# Altium schematic sheet BMS_TopLevel.SchDoc
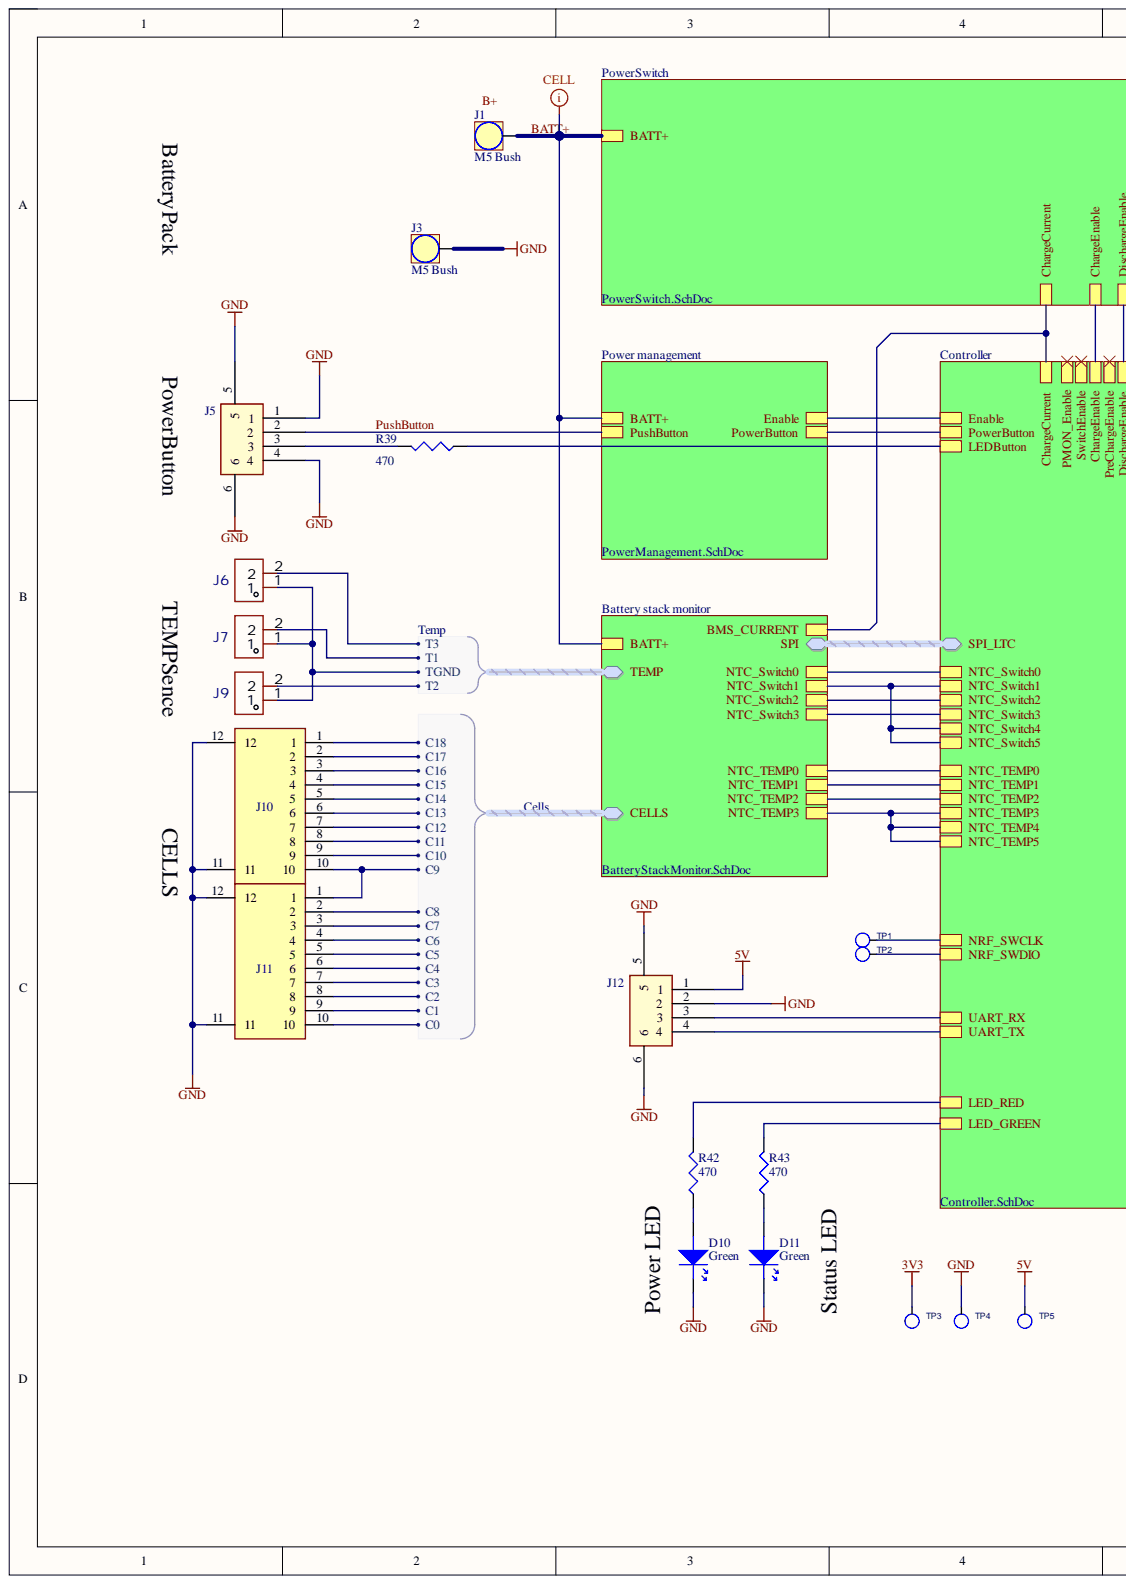
[diagram p0: BMS_TopLevel.SchDoc, left]
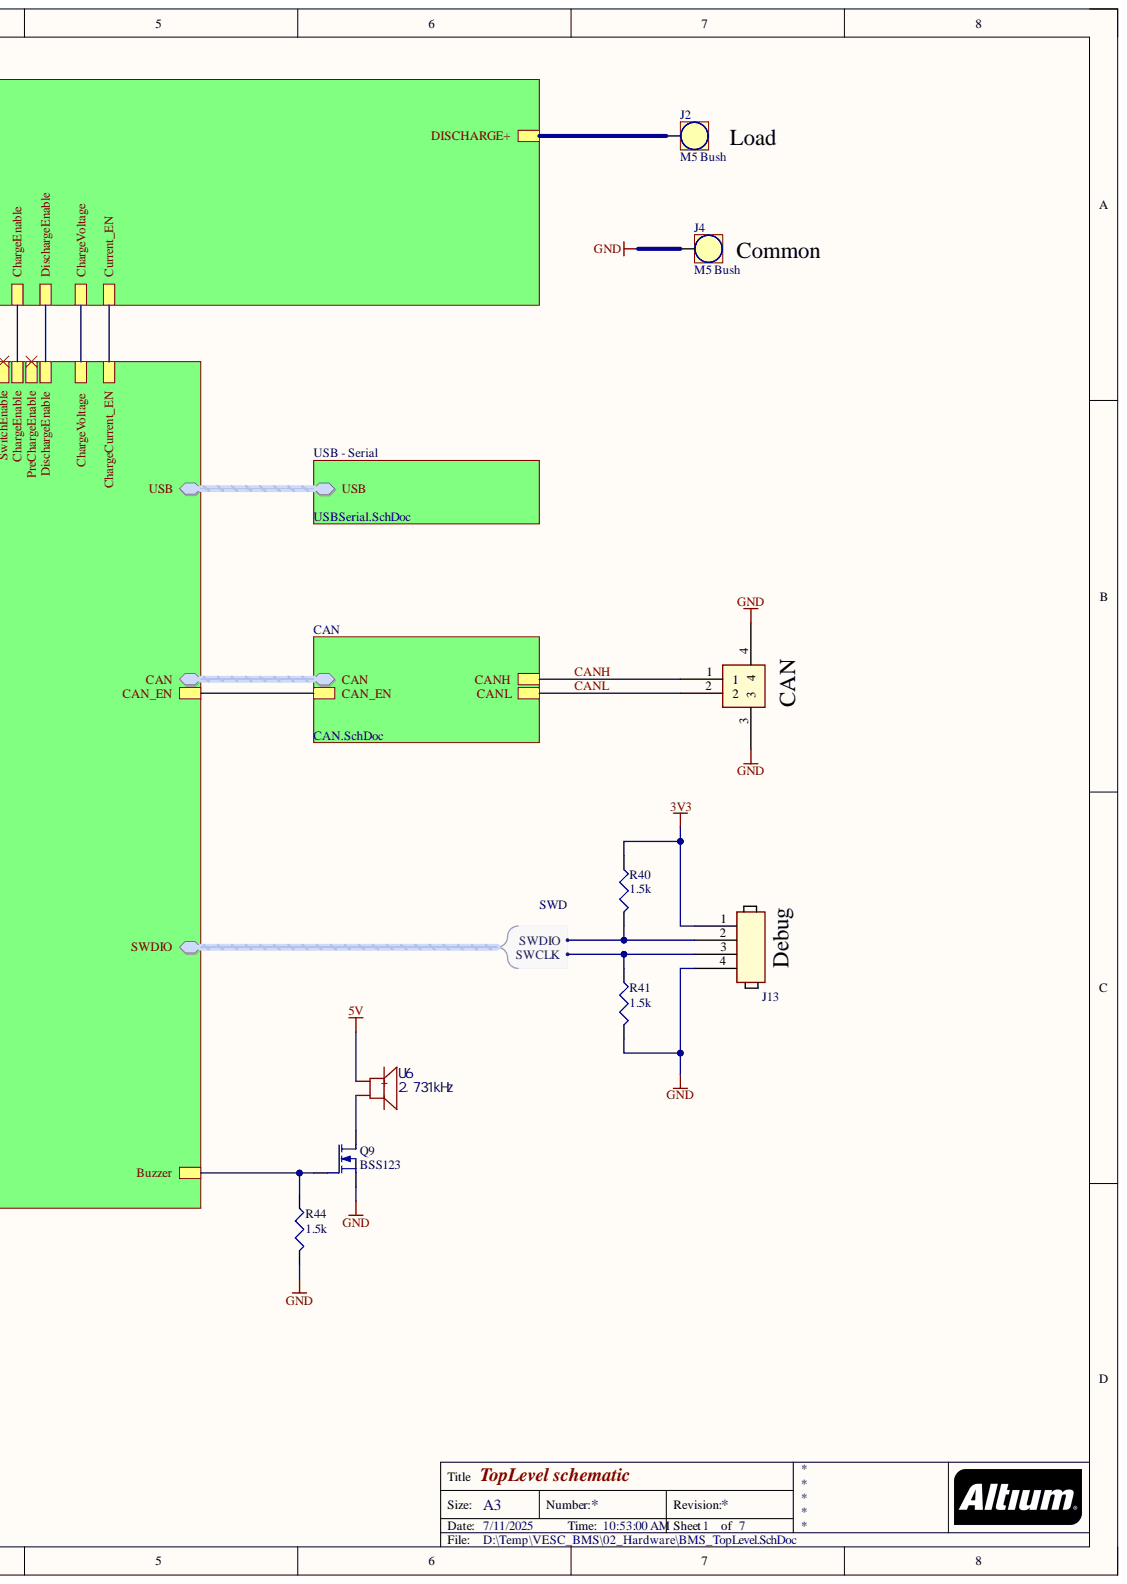
[diagram p1: BMS_TopLevel.SchDoc, right]

## Parts
D10  Green  [LED0603]
D11  Green  [LED0603]
J1  M5 Bush  [CONN-TH_T44001]
J2  M5 Bush  [CONN-TH_T44001]
J3  M5 Bush  [CONN-TH_T44001]
J4  M5 Bush  [CONN-TH_T44001]
J5  1.25-4PWTDK  [SM04B-GHS-TB]  (value not drawn)
J6  WAFER-XH254Z-2A  [CONN-TH_2P-P2.50_XH-2AW]  (value not drawn)
J7  WAFER-XH254Z-2A  [CONN-TH_2P-P2.50_XH-2AW]  (value not drawn)
J8  1.25-2PWTDK  [BM02B-GHS-TBT_1]  (value not drawn)
J9  WAFER-XH254Z-2A  [CONN-TH_2P-P2.50_XH-2AW]  (value not drawn)
J10  S10B-PH-SM4-TB_LF__SN_  [S10B-PH-SM4-TB]  (value not drawn)
J11  S10B-PH-SM4-TB_LF__SN_  [S10B-PH-SM4-TB]  (value not drawn)
J12  1.25-4PWTDK  [SM04B-GHS-TB]  (value not drawn)
J13  4 HEADER  [PZ-4P-2_54]  (value not drawn)
Q9  BSS123  [SOT-23]
R39  470  [0402]
R40  1.5k  [0402]
R41  1.5k  [0402]
R42  470  [0402]
R43  470  [0402]
R44  1.5k  [0402]
TP1  1.3TP  [TP2-1.3MM]  (value not drawn)  (no BOM)
TP2  1.3TP  [TP2-1.3MM]  (value not drawn)  (no BOM)
TP3  1.3TP  [TP2-1.3MM]  (value not drawn)  (no BOM)
TP4  1.3TP  [TP2-1.3MM]  (value not drawn)  (no BOM)
TP5  1.3TP  [TP2-1.3MM]  (value not drawn)  (no BOM)
U6  2.731kHz  [BUZ-SMD_4P-L7.5-W7.5-BR]

## Nets
3V3: J13.1 R40.1 TP3.1
5V: J12.1 TP5.1 U6.1
GND: D10.1 D11.1 J3.1 J4.1 J5.1 J5.4 J5.5 J5.6 J8.3 J8.4 J10.11 J10.12 J11.11 J11.12 J12.2 J12.5 J12.6 J13.4 Q9.S R41.2 R44.2 TP4.1
BATT+: J1.1
CANH: J8.1
CANL: J8.2
PushButton: J5.2
NetD10_2: D10.2 R42.1
NetD11_2: D11.2 R43.1
NetJ2_1: J2.1
NetJ5_3: J5.3 R39.2
NetJ6_1: J6.1 J7.1 J9.1
NetJ6_2: J6.2
NetJ7_2: J7.2
NetJ9_2: J9.2
NetJ10_1: J10.1
NetJ10_2: J10.2
NetJ10_3: J10.3
NetJ10_4: J10.4
NetJ10_5: J10.5
NetJ10_6: J10.6
NetJ10_7: J10.7
NetJ10_8: J10.8
NetJ10_9: J10.9
NetJ10_10: J10.10 J11.1
NetJ11_2: J11.2
NetJ11_3: J11.3
NetJ11_4: J11.4
NetJ11_5: J11.5
NetJ11_6: J11.6
NetJ11_7: J11.7
NetJ11_8: J11.8
NetJ11_9: J11.9
NetJ11_10: J11.10
NetJ12_3: J12.3
NetJ12_4: J12.4
NetJ13_2: J13.2 R40.2
NetJ13_3: J13.3 R41.1
NetQ9_D: Q9.D U6.2
NetQ9_G: Q9.G R44.1
NetR39_1: R39.1
NetR42_2: R42.2
NetR43_2: R43.2
NetTP1_1: TP1.1
NetTP2_1: TP2.1
NetU6_3: U6.3
NetU6_4: U6.4(+)
B+:
BMS_CURRENT:
CAN.RXD:
CAN.TXD:
CAN_EN:
ChargeCurrent_EN:
ChargeEnable:
ChargeVoltage:
DischargeEnable:
Enable:
NTC_Switch0:
NTC_Switch1:
NTC_Switch2:
NTC_Switch3:
NTC_TEMP0:
NTC_TEMP1:
NTC_TEMP2:
NTC_TEMP3:
PMON_Enable:
PowerButton:
PreChargeEnable:
SPI.MISO:
SPI.MOSI:
SPI.~{SS}:
SPI.SCK:
SwitchEnable:
USB.DM:
USB.DP:

## Library data of the parts
D10 D11 = KP-2012SGC: Led, Green, Li:12mcd, Vf:2.2V, If:0.02A; Brand: KingBright; Case Style: 0805; Forward Current: 0.02A; Forward Voltage: 2.2V; Luminous Intensity: 12mcd; Brand Number: KP-2012SGC; Component Kind: Standard; Order Code Supplier: 1318243; Price: 0,06; Supplier: Farnell; Temperature Range: -30°C to +85°C; Library Name: VESC_BMS.SCHLIB
J1-J4 = T44001: Bush with Circumference M4; Brand: Wurth; Brand Number: 7460408; Case Style: M5Bush; Component Kind: Standard; Order Code Supplier: 1821279; Price: 4,17; Supplier: Farnell; Library Name: VESC_BMS.SCHLIB
J6 J7 J9 = WAFER-XH254Z-2A: Manufacturer: HANBO(汉博); Add into BOM: yes; Current Rating (Max): 3A; Number of PINs Per Row: 2; Pins Structure: 1x2P; Pitch: 2.54mm; Reference Series: XH; Component Kind: Standard; Convert to PCB: yes; Datasheet: https://atta.szlcsc.com/upload/public/pdf/source/20230718/3B4FABA5D38EFB02DAF74E7C2B33D98F.pdf; Device: WAFER-XH254Z-2A; LCSC Part Name: WAFER-XH254Z-2A; Manufacturer Part: WAFER-XH254Z-2A; Mounting Style: 直插; Number of Pins: 2P; Number of Rows: 1; Operating Temperature Range: -25℃~+85℃; Supplier: LCSC; Supplier Footprint: 插件,P=2.5mm; Supplier Part: C7432682; Symbol: WAFER-XH254Z-2A; Library Name: VESC_BMS.SCHLIB
J10 J11 = S10B-PH-SM4-TB_LF__SN_: Connector; Manufacturer_Name: JST (JAPAN SOLDERLESS TERMINALS); Manufacturer_Part_Number: S10B-PH-SM4-TB(LF)(SN); Arrow Part Number: S10B-PH-SM4-TB(LF)(SN); Arrow Price/Stock: https://www.arrow.com/en/products/s10b-ph-sm4-tb-lf-sn/jst-manufacturing?region=nac; Component Kind: Standard; Datasheet Link: http://uk.rs-online.com/web/p/products/6881350; Mouser Part Number: 306-S10BPHSM4TBLFSN; Mouser Price/Stock: https://www.mouser.co.uk/ProductDetail/JST-Commercial/S10B-PH-SM4-TBLFSN?qs=QpmGXVUTftH7ZRPAPdrNVw%3D%3D; Library Name: DB10005_DieBieMS.SCHLIB
Q9 = BSS123: Mosfet, N-channel, Vds:100V, Id:0.15A, Vgs-th:2.8V, Rds-on:3.5ohm; Brand: NXP; Drain Current: 0.15A; Drain Source Voltage: 100V; Gate Source Voltage: 20V; On Resistance: 3.5ohm; Threshold Voltage: 2.8V; Brand Number: BSS123; Case Style: SOT-23; Component Kind: Standard; Library Name: AR_Mosfets.IntLib; Order Code Supplier: 1510764; Price: 0,082; Supplier: Farnell; Temperature Range: -55°C to +150°C; Transistor Polarity: N-channel
R39 R42 R43 = 470/0402/0063W/50V: Resistor, 470, 1%, 0.0625W, 50V, 100PPM, 0402; Brand: VISHAY DRALORIC; Brand Number: CRG0402F470R; Power Rating: 0.063W; Case Style: 0402; Component Kind: Standard; Library Name: AR_Resistors_SMD.IntLib; Order Code Supplier: 2331533; Price: 0,0099; Resistance: 470; Supplier: Farnell; Temperature Coefficient: ± 100PPM; Temperature Range: -55°C to +125°C; Voltage Rating: 50V
R40 R41 R44 = 1.5k/0402/0063W/50V: Resistor, 1.5k, 0.1%, 0.0625W, 50V, 100PPM, 0402; Brand: VISHAY DRALORIC; Brand Number: CRCW04021K50FKED; Power Rating: 0.063W; Case Style: 0402; Component Kind: Standard; Library Name: AR_Resistors_SMD.IntLib; Order Code Supplier: 2121624; Price: 0,0054; Resistance: 1.5k; Supplier: Farnell; Temperature Coefficient: ± 100PPM; Temperature Range: -55°C to +125°C; Voltage Rating: 50V
TP1-TP5 = TESTPT4: 1.3mm; Library Name: AR_Misc.IntLib
U6 = HYG-7525A: Manufacturer: HYDZ(华宇); Add into BOM: yes; Current Consumption: 90mA; Sound Pressure Level(SPL): 85dB; Component Kind: Standard; Convert to PCB: yes; Datasheet: https://atta.szlcsc.com/upload/public/pdf/source/20230825/78098EC44B170663F3151CF5366FAD5D.pdf; Device: HYG-7525A; JLCPCB Part Class: 扩展库; LCSC Part Name: 贴片无源蜂鸣器; Manufacturer Part: HYG-7525A; Supplier: LCSC; Supplier Footprint: SMD,7.5x7.5mm; Supplier Part: C7544812; Symbol: HYG-7525A; Value: 2.731kHz; Library Name: VESC_BMS.SCHLIB
J5 J12 = 1.25-4PWTDK: Component Kind: Standard; Library Name: VESC_BMS.SCHLIB
J8 = 1.25-2PWTDK: Component Kind: Standard; Library Name: DB10005_DieBieMS.SCHLIB
J13 = 4 HEADER: Component Kind: Standard; Library Name: VESC_BMS.SCHLIB
